# Altium schematic sheet schematic.SchDoc(schematic)
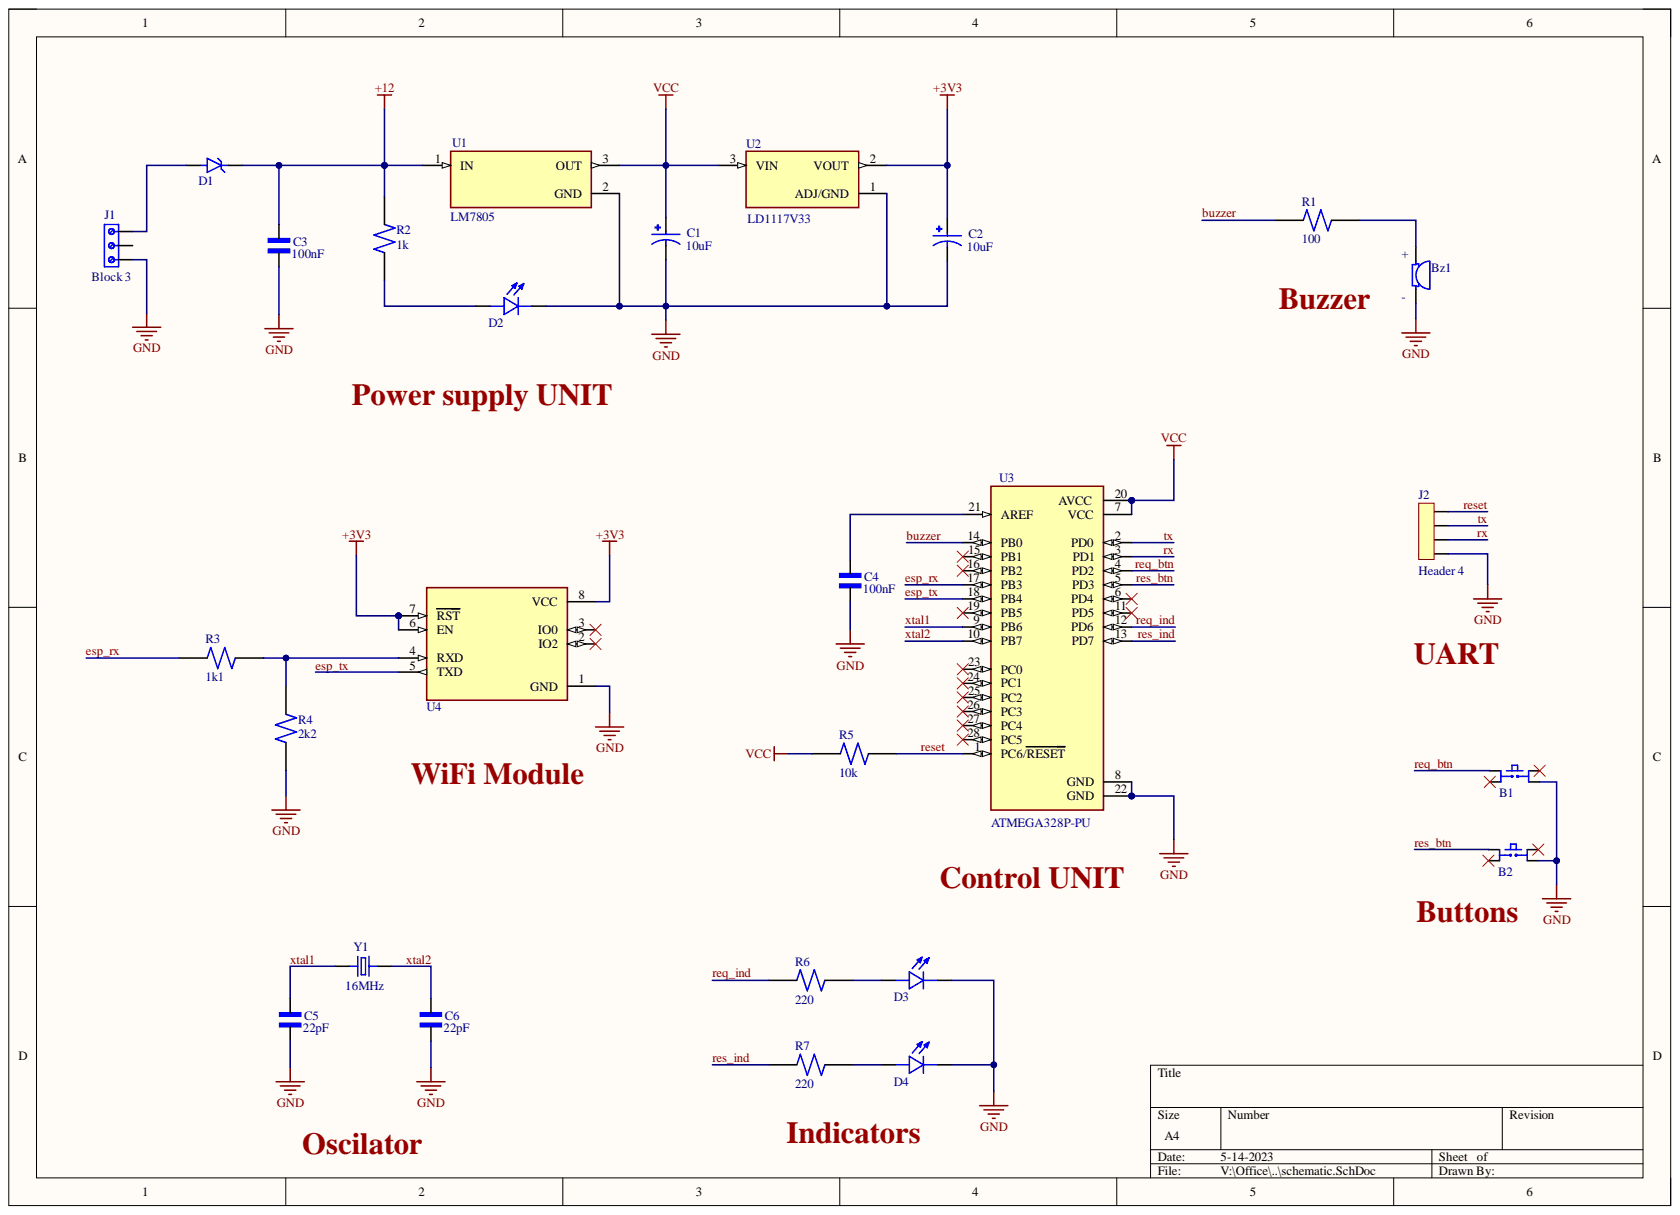
[diagram p0: schematic.SchDoc]

## Parts
B1  Push button  [SW_TS02-66-95-BK-260-LCR-D]  (value not drawn)
B2  Push button  [SW_TS02-66-95-BK-260-LCR-D]  (value not drawn)
Bz1  AI-1223-TWT-12V-R  [XDCR_AI-1223-TWT-12V-R]  (value not drawn)
C1  10uF  [CAPPRD500W50D500H1100]
C2  10uF  [CAPPRD500W50D500H1100]
C3  100nF  [CAPRB500W50L450T300H550]
C4  100nF  [CAPRB500W50L450T300H550]
C5  22pF  [CAPRB500W50L450T300H550]
C6  22pF  [CAPRB500W50L450T300H550]
D1  1N4745A  [DIOAD1060W70L435D230]  (value not drawn)
D2  L08R5000Q1  [LEDRD254W57D500H1070]  (value not drawn)
D3  L08R5000Q1  [LEDRD254W57D500H1070]  (value not drawn)
D4  L08R5000Q1  [LEDRD254W57D500H1070]  (value not drawn)
J1  Block 3  [691214110003]
J2  Header 4  [SULLINS_PPTC041LFBN-RC]
R1  100  [RESAD1100W55L680D260]
R2  1k  [RESAD1100W55L680D260]
R3  1k1  [RESAD1100W55L680D260]
R4  2k2  [RESAD1100W55L680D260]
R5  10k  [RESAD1100W55L680D260]
R6  220  [RESAD1100W55L680D260]
R7  220  [RESAD1100W55L680D260]
U1  LM7805  [TO254P1054X470X1955-3]
U2  LD1117V33  [TO255P1020X450X1968-3]
U3  ATMEGA328P-PU  [DIP794W46P254L2967H457Q28B]
U4  ESP8266-01/ESP-01  [XCVR_ESP8266-01/ESP-01]  (value not drawn)
Y1  16MHz  [XTAL_ECS-160-20-4X]

## Nets
+3V3: C2.P U2.2 U4.6(EN) U4.7(RST) U4.8(VCC)
+12: C3.2 D1.C R2.2 U1.1
GND: B1.4 B2.4 Bz1.N C1.N C2.N C3.1 C4.1 C5.1 C6.1 D2.C D3.C D4.C J1.3 J2.4 R4.1 U1.2 U2.1 U3.8(GND) U3.22(GND) U4.1(GND)
VCC: C1.P R5.2 U1.3 U2.3 U3.7(VCC) U3.20(AVCC)
buzzer: R1.2 U3.14(PB0)
esp_rx: R3.2 U3.17(PB3)
esp_tx: U3.18(PB4) U4.5(TXD)
req_btn: B1.1 U3.4(PD2)
req_ind: R6.2 U3.12(PD6)
res_btn: B2.1 U3.5(PD3)
res_ind: R7.2 U3.13(PD7)
reset: J2.1 R5.1 U3.1(PC6/RESET)
rx: J2.3 U3.3(PD1)
tx: J2.2 U3.2(PD0)
xtal1: C5.2 U3.9(PB6) Y1.1
xtal2: C6.2 U3.10(PB7) Y1.2
NetBz1_P: Bz1.P R1.1
NetC4_2: C4.2 U3.21(AREF)
NetD1_A: D1.A J1.1
NetD2_A: D2.A R2.1
NetD3_A: D3.A R6.1
NetD4_A: D4.A R7.1
NetR3_1: R3.1 R4.2 U4.4(RXD)

Not connected: B1 2 3; B2 2 3; J1 2; U3 6(PD4) 11(PD5) 15(PB1) 16(PB2) 19(PB5) 23(PC0) 24(PC1) 25(PC2) 26(PC3) 27(PC4) 28(PC5); U4 2(IO2) 3(IO0)

## Library data of the parts
Bz1 = Buzzer: ELECTRO-MECHANICAL AUDIO INDICATOR; MF: PUI Audio,; Availability: In Stock; Component Kind: Standard; MP: AI-1223-TWT-12V-R; Package: 2225 PUI Audio; Price: None; Purchase-URL: https://pricing.snapeda.com/search/part/AI-1223-TWT-12V-R/?ref=eda; Library Name: Installed library.IntLib
C1 C2 = Electrolytic capacitor: FS-A; MF: {'manufacturer': u'Panasonic'}; MP: EEUFS0J221B; Availability: Unavailable; Component Kind: Standard; Package: None; Price: None; Library Name: Installed library.IntLib
C3-C6 = Ceramic capacitor: 2200 pF Â±10% 250V Ceramic Capacitor X7R Radial; MF: TDK; MP: FG24X7R2E222KNT06; Check_prices: https://www.snapeda.com/parts/FG24X7R2E222KNT06/TDK/view-part/?ref=eda; Component Kind: Standard; Digi-Key_Part_Number: 445-173386-1-ND; Package: Radial TDK; Purchase-URL: https://pricing.snapeda.com/search/part/FG24X7R2E222KNT06/?ref=eda; SnapEDA_Link: https://www.snapeda.com/parts/FG24X7R2E222KNT06/TDK/view-part/?ref=snap; Library Name: Installed library.IntLib
D1 = Zenner diode: Zener Diode 16V 1W Â±5% Through Hole DO-204AL (DO-41); MF: ON Semiconductor; Availability: In Stock; Check_prices: https://www.snapeda.com/parts/1N4745A/Onsemi/view-part/?ref=eda; Component Kind: Standard; MP: 1N4745A; Package: DO-41-2 ON Semiconductor; Price: None; Purchase-URL: https://pricing.snapeda.com/search/part/1N4745A/?ref=eda; SnapEDA_Link: https://www.snapeda.com/parts/1N4745A/Onsemi/view-part/?ref=snap; Library Name: Installed library.IntLib
D2-D4 = LED: LED, Orange, Through Hole, T-1 3/4 (5mm), 30 mA, 2.1 V, 635 nm; MF: {'manufacturer': u'LED Technology'}; Availability: Unavailable; Component Kind: Standard; MP: L08R5000Q1; Package: None; Price: None; Library Name: Installed library.IntLib
J1 = Terminal blocks - 3Pins (Small): 3 Position Wire to Board Terminal Block Horizontal with Board 0.138 (3.50mm) Through Hole; MF: Wurth Elektronik; Availability: In Stock; Check_prices: https://www.snapeda.com/parts/691214110003/Wurth+Elektronik/view-part/?ref=eda; Component Kind: Standard; MP: 691214110003; Package: None; Price: None; Purchase-URL: https://pricing.snapeda.com/search/part/691214110003/?ref=eda; SnapEDA_Link: https://www.snapeda.com/parts/691214110003/Wurth+Elektronik/view-part/?ref=snap; Library Name: Installed library.IntLib
J2 = Female header - 4Pins: 4 Position Header Connector Through Hole; MF: Sullins Connector; MP: PPTC041LFBN-RC; Availability: Warning; Check_prices: https://www.snapeda.com/parts/PPTC041LFBN-RC/Sullins%20Connector%20Solutions/view-part/285917/?ref=eda; Component Kind: Standard; Package: None; Price: None; Purchase-URL: https://pricing.snapeda.com/search/part/PPTC041LFBN-RC/?ref=eda; SnapEDA_Link: https://www.snapeda.com/parts/PPTC041LFBN-RC/Sullins%20Connector%20Solutions/view-part/285917/?ref=snap; Library Name: Installed library.IntLib
R1-R7 = Resistor (0.25W): (MFR-25FBF52-10K) 10,2 kOhm bei 1% 0,25W, 1/4W Durchbohrlochwiderstand Axial Metal Film; MF: Yageo; Component Kind: Standard; Digi-Key_Part_Number: 10.2KXBK-ND; MP: MFR-25FBF52-10K; Package: Axial Yageo; Purchase-URL: https://pricing.snapeda.com/search/part/MFR-25FBF52-10K/?ref=eda; SnapEDA_Link: https://www.snapeda.com/parts/MFR-25FBF52-10K/Yageo/view-part/424333/?ref=snap; Library Name: Installed library.IntLib
U1 = LM7805: MP: LM7805CT/NOPB; Availability: Unavailable; Component Kind: Standard; Package: None; Price: None; Library Name: Installed library.IntLib
U3 = ATMEGA328P-PU: ATmega Series 20 MHz 32 KB Flash 2 KB SRAM 8-Bit Microcontroller - DIP-28; Availability: Unavailable; Component Kind: Standard; MP: ATMEGA328P-PU; Package: None; Price: None; Library Name: Installed library.IntLib
U4 = ESP8266-01 Module: MakerFocus 4pcs ESP8266 ESP-01 Serial Wireless WiFi Transceiver Receiver Module 1MB SPI Flash DC3.0-3.6V Internet of Things WiFi Module Board Compatible with Ar duino; Availability: Unavailable; Component Kind: Standard; MP: ESP8266-01/ESP-01; Package: None; Price: None; Library Name: Installed library.IntLib
Y1 = Crystal oscilator: CRYSTAL T/H; MF: {'manufacturer': u'ECS Inc.'}; MP: ECS-160-S-4; Availability: Unavailable; Component Kind: Standard; Package: None; Price: None; Library Name: Installed library.IntLib
B1 B2 = Push button: Component Kind: Standard; Library Name: Installed library.IntLib
U2 = LD1117V33: Availability: Unavailable; Component Kind: Standard; MP: LD1117V33; Package: None; Price: None; Library Name: Installed library.IntLib
